# Altium schematic sheet 11_USB.SchDoc
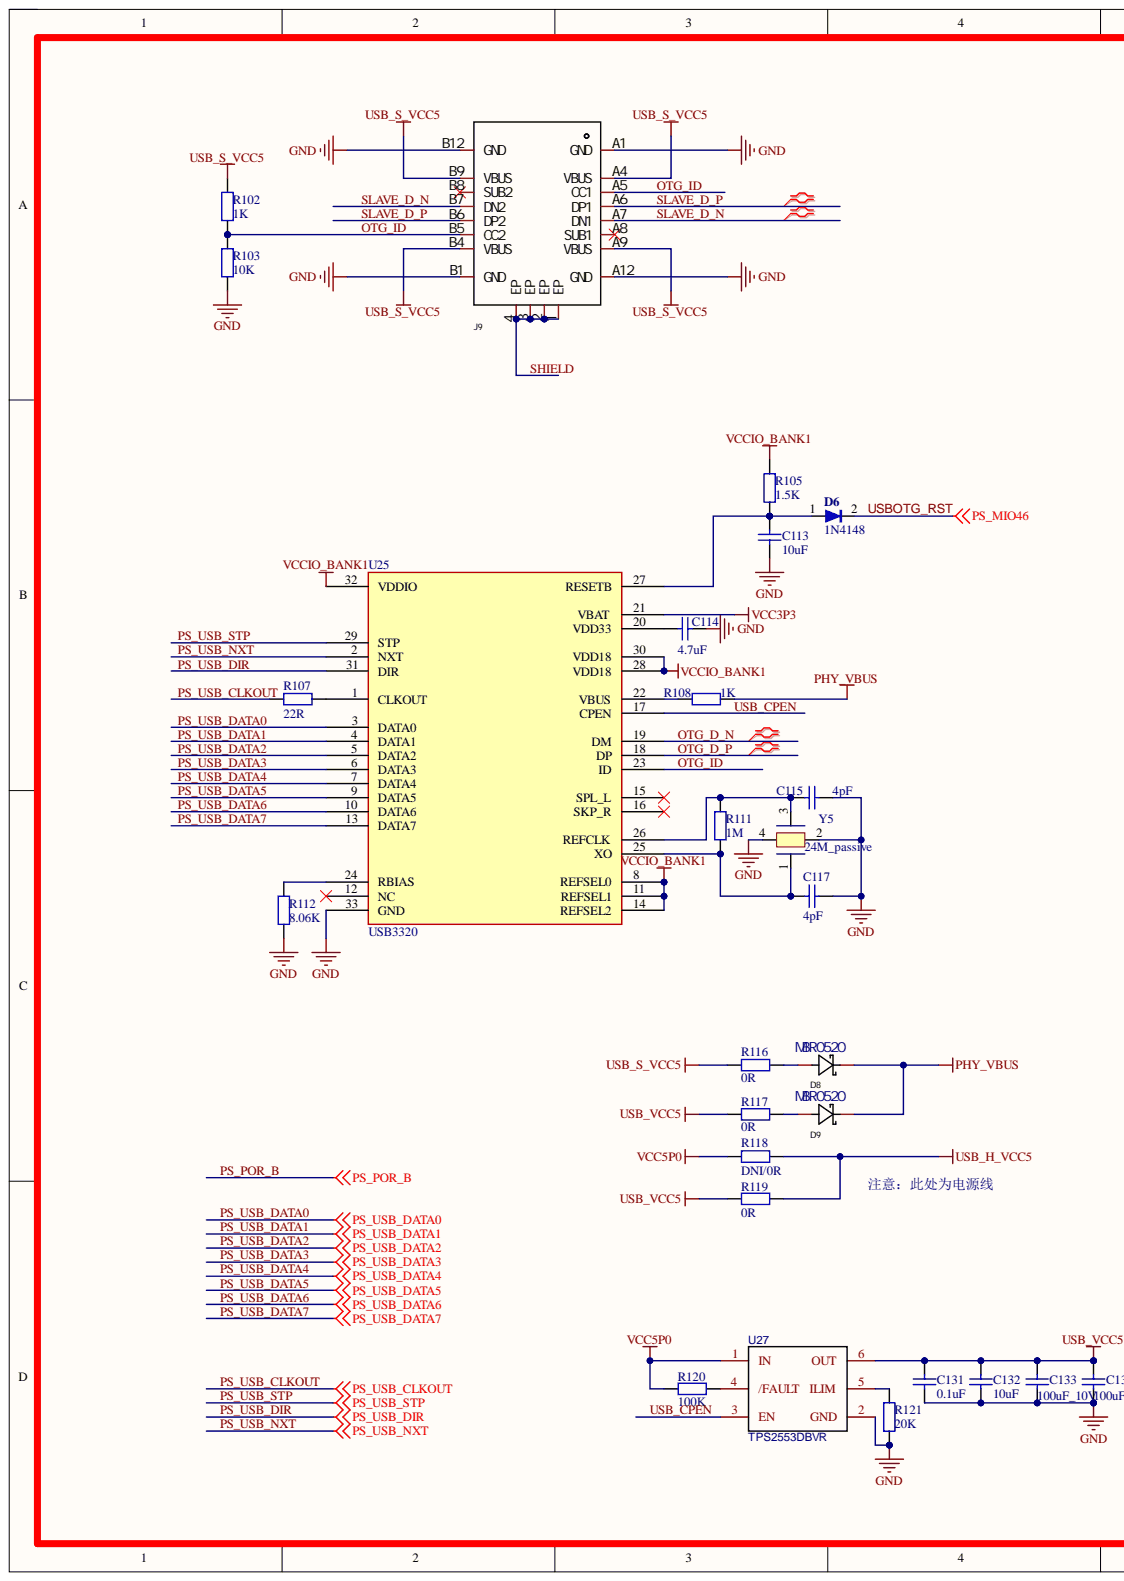
[diagram p0: 11_USB.SchDoc, left]
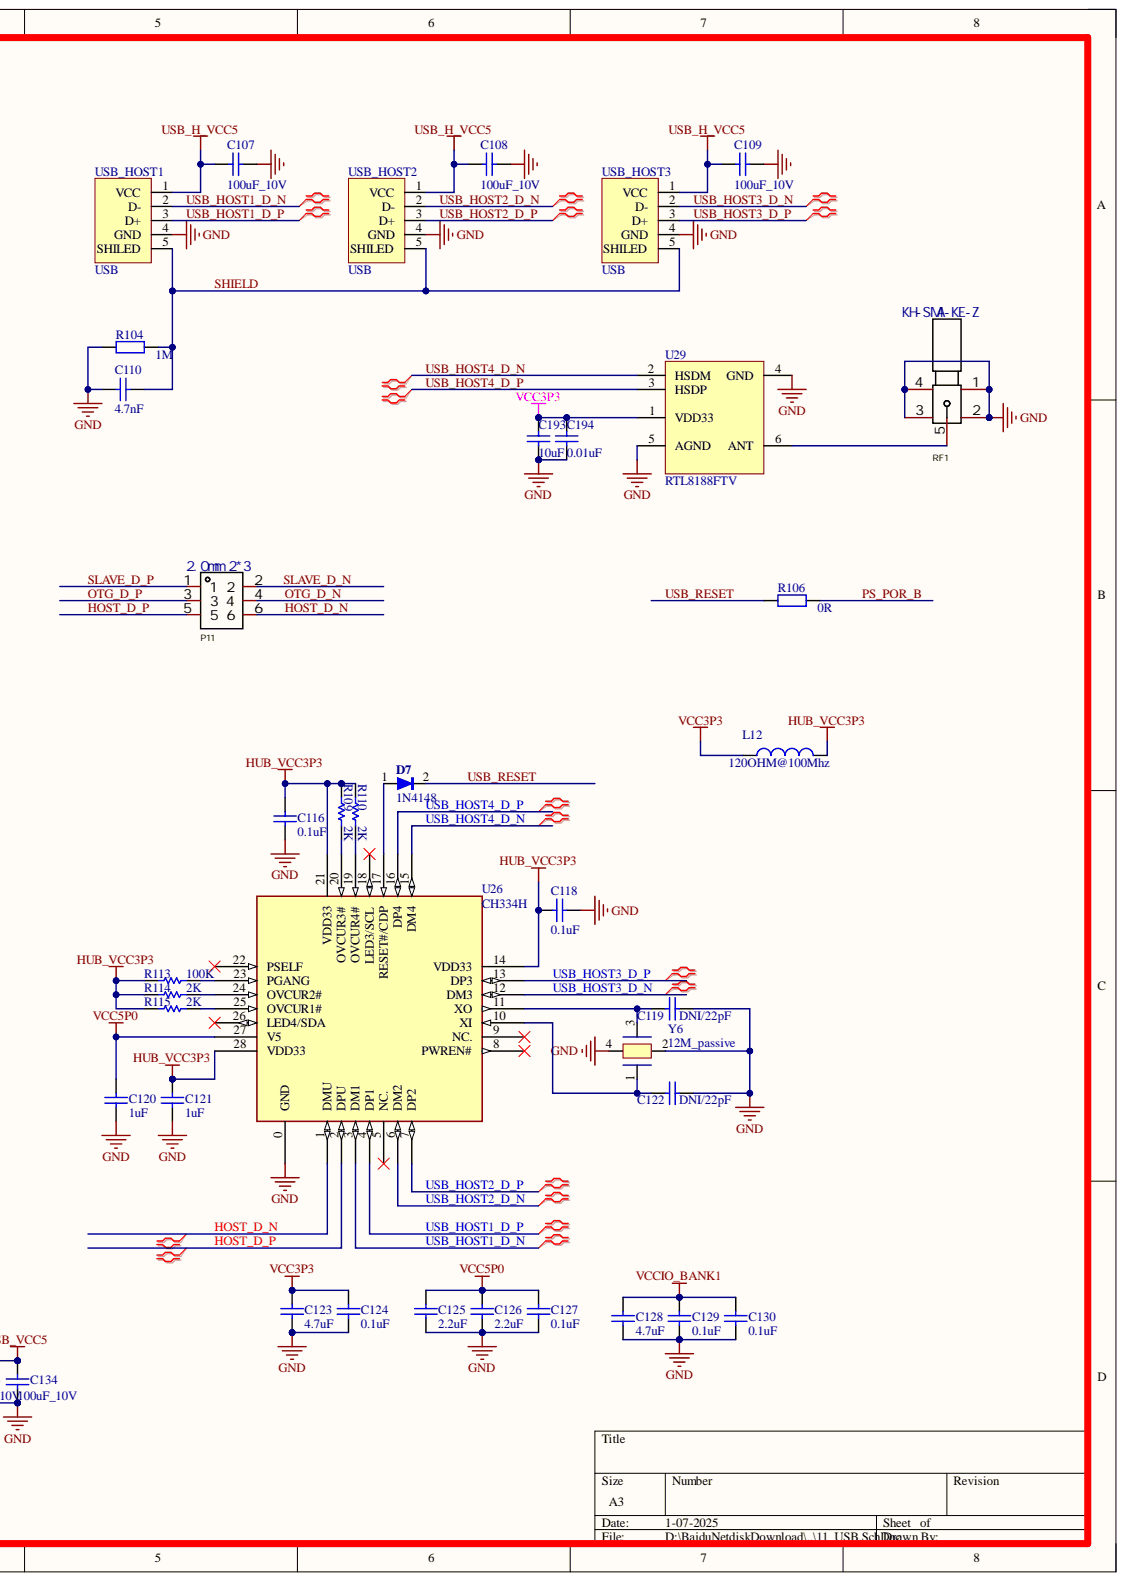
[diagram p1: 11_USB.SchDoc, right]

## Parts
C107  100uF_10V  [C1206]
C108  100uF_10V  [C1206]
C109  100uF_10V  [C1206]
C110  4.7nF  [C1206]
C113  10uF  [C0805]
C114  4.7uF  [C0603]
C115  4pF  [C0603]
C116  0.1uF  [C0603]
C117  4pF  [C0603]
C118  0.1uF  [C0603]
C119  DNI/22pF  [C0603]
C120  1uF  [C0603]
C121  1uF  [C0603]
C122  DNI/22pF  [C0603]
C123  4.7uF  [C0603]
C124  0.1uF  [C0603]
C125  2.2uF  [C0603]
C126  2.2uF  [C0603]
C127  0.1uF  [C0603]
C128  4.7uF  [C0603]
C129  0.1uF  [C0603]
C130  0.1uF  [C0603]
C131  0.1uF  [C0603]
C132  10uF  [C0805]
C133  100uF_10V  [C1206]
C134  100uF_10V  [C1206]
C193  10uF  [C0805]
C194  0.01uF  [C0603]
D6  value 1N4148  [SOD323]  (hidden comment: DIODE1)
D7  1N4148  [SOD323]
D8  MBR0520  [sod-123_l2_7-w1_6-ls3_7-rd-1]
D9  MBR0520  [sod-123_l2_7-w1_6-ls3_7-rd-1]
J9  KH-TYPE-C-16P  [USB_Type-c]  (value not drawn)
L12  120OHM@100Mhz  [L0603]
P11  2.0mm 2*3  [hdr-th_6p-p2_00-v-m-r2-c3-s2_00]
R102  1K  [0603R]
R103  10K  [0603R]
R104  1M  [R1206]
R105  1.5K  [0603R]
R106  0R  [R0603]
R107  22R  [0603R]
R108  1K  [0603R]
R109  2K  [0603R]
R110  2K  [0603R]
R111  1M  [0603R]
R112  8.06K  [0603R]
R113  100K  [0603R]
R114  2K  [0603R]
R115  2K  [0603R]
R116  0R  [R0603]
R117  0R  [R0603]
R118  DNI/0R  [0603R]
R119  0R  [R0603]
R120  100K  [0603R]
R121  20K  [0603R]
RF1  KH-SMA-KE-Z  [SMA-TH_KH-SMA-KE-Z]
U25  USB3320  [qfn-32_l5_0-w5_0-p0_50-bl-ep3_4]
U26  CH334H  [QFN28_5X5]
U27  TPS2553DBVR  [SOT-23-6]
U29  RTL8188FTV  [RL8188FTV]
USB_HOST1  USB  [usb-a_s_e]
USB_HOST2  USB  [usb-a_s_e]
USB_HOST3  USB  [usb-a_s_e]
Y5  24M_passive  [X4-3225]
Y6  12M_passive  [X4-3225]

## Nets
GND: C107.1 C108.1 C109.1 C110.2 C113.1 C114.2 C115.1 C116.2 C117.1 C118.1 C119.1 C120.2 C121.2 C122.1 C123.1 C124.1 C125.1 C126.1 C127.1 C128.1 C129.1 C130.1 C131.1 C132.1 C133.1 C134.1 C193.1 C194.1 J9.A1(GND) J9.A12(GND) J9.B1(GND) J9.B12(GND) R103.1 R104.2 R112.1 R121.2 RF1.1 RF1.2 RF1.3 RF1.4 U25.33(GND) U26.0(GND) U27.2(GND) U29.4(GND) U29.5(AGND) USB_HOST1.4(GND) USB_HOST2.4(GND) USB_HOST3.4(GND) Y5.2 Y5.4 Y6.2 Y6.4
HUB_VCC3P3: C116.1 C118.2 C121.1 L12.2 R109.1 R110.1 R113.1 R114.1 R115.1 U26.14(VDD33) U26.21(VDD33) U26.28(VDD33)
PHY_VBUS: D8.1 D9.1 R108.1
USB_H_VCC5: C107.2 C108.2 C109.2 R118.1 R119.2 USB_HOST1.1(VCC) USB_HOST2.1(VCC) USB_HOST3.1(VCC)
USB_S_VCC5: J9.A4(VBUS) J9.A9(VBUS) J9.B4(VBUS) J9.B9(VBUS) R102.2 R116.2
USB_VCC5: C131.2 C132.2 C133.2 C134.2 R117.1 R119.1 U27.6(OUT)
VCC3P3: C123.2 C124.2 C193.2 C194.2 L12.1 U25.21(VBAT) U29.1(VDD33)
VCC5P0: C120.1 C125.2 C126.2 C127.2 R118.2 R120.1 U26.27(V5) U27.1(IN)
VCCIO_BANK1: C128.2 C129.2 C130.2 R105.1 U25.8(REFSEL0) U25.11(REFSEL1) U25.14(REFSEL2) U25.28(VDD18) U25.30(VDD18) U25.32(VDDIO)
HOST_D_N: P11.6 U26.1(DMU)
HOST_D_P: P11.5 U26.2(DPU)
OTG_D_N: P11.4 U25.19(DM)
OTG_D_P: P11.3 U25.18(DP)
OTG_ID: J9.A5(CC1) J9.B5(CC2) R102.1 R103.2 U25.23(ID)
PS_POR_B: R106.1
PS_USB_CLKOUT: R107.2
PS_USB_DATA0: U25.3(DATA0)
PS_USB_DATA1: U25.4(DATA1)
PS_USB_DATA2: U25.5(DATA2)
PS_USB_DATA3: U25.6(DATA3)
PS_USB_DATA4: U25.7(DATA4)
PS_USB_DATA5: U25.9(DATA5)
PS_USB_DATA6: U25.10(DATA6)
PS_USB_DATA7: U25.13(DATA7)
PS_USB_DIR: U25.31(DIR)
PS_USB_NXT: U25.2(NXT)
PS_USB_STP: U25.29(STP)
SHIELD: C110.1 J9.1(EP) J9.2(EP) J9.3(EP) J9.4(EP) R104.1 USB_HOST1.5(SHILED) USB_HOST2.5(SHILED) USB_HOST3.5(SHILED)
SLAVE_D_N: J9.A7(DN1) J9.B7(DN2) P11.2
SLAVE_D_P: J9.A6(DP1) J9.B6(DP2) P11.1
USB_CPEN: U25.17(CPEN) U27.3(EN)
USB_HOST1_D_N: U26.3(DM1) USB_HOST1.2(D-)
USB_HOST1_D_P: U26.4(DP1) USB_HOST1.3(D+)
USB_HOST2_D_N: U26.6(DM2) USB_HOST2.2(D-)
USB_HOST2_D_P: U26.7(DP2) USB_HOST2.3(D+)
USB_HOST3_D_N: U26.12(DM3) USB_HOST3.2(D-)
USB_HOST3_D_P: U26.13(DP3) USB_HOST3.3(D+)
USB_HOST4_D_N: U26.15(DM4) U29.2(HSDM)
USB_HOST4_D_P: U26.16(DP4) U29.3(HSDP)
USB_RESET: D7.2 R106.2
USBOTG_RST: D6.2
NetC113_2: C113.2 D6.1 R105.2 U25.27(RESETB)
NetC114_1: C114.1 U25.20(VDD33)
NetC115_2: C115.2 R111.2 U25.26(REFCLK) Y5.3
NetC117_2: C117.2 R111.1 U25.25(XO) Y5.1
NetC119_2: C119.2 U26.11(XO) Y6.3
NetC122_2: C122.2 U26.10(XI) Y6.1
NetD7_1: D7.1 U26.17(RESET#/CDP)
NetD8_2: D8.2 R116.1
NetD9_2: D9.2 R117.2
NetR107_1: R107.1 U25.1(CLKOUT)
NetR108_2: R108.2 U25.22(VBUS)
NetR109_2: R109.2 U26.20(OVCUR3#)
NetR110_2: R110.2 U26.19(OVCUR4#)
NetR112_2: R112.2 U25.24(RBIAS)
NetR113_2: R113.2 U26.23(PGANG)
NetR114_2: R114.2 U26.24(OVCUR2#)
NetR115_2: R115.2 U26.25(OVCUR1#)
NetR120_2: R120.2 U27.4(/FAULT)
NetR121_1: R121.1 U27.5(ILIM)
NetRF1_5: RF1.5 U29.6(ANT)

Not connected: J9 A8(SUB1) B8(SUB2); U25 12(NC) 15(SPL_L) 16(SKP_R); U26 5(NC.) 8(PWREN#) 9(NC.) 18(LED3/SCL) 22(PSELF) 26(LED4/SDA)

## Library data of the parts
C107-C110 C113-C115 C117 C123-C134 C193 C194 = Cap: Capacitor; Component Kind: Standard; LatestRevisionDate: 17-Jul-2002; LatestRevisionNote: Re-released for DXP Platform.; Library Name: Miscellaneous Devices.IntLib; PackageDescription: Radial Cap, Thru-Hole; 2 Leads; 0.3 in Pin Spacing; PackageReference: RAD-0.3; Published: 8-Jun-2000; Signal Integrity: Cap; Simulation: CAP; Publisher: Altium Limited
  C107-C109 C133 C134: Value: 100uF_10V
  C110: Value: 4.7nF
  C113 C132 C193: Value: 10uF
  C114 C123 C128: Value: 4.7uF
  C115 C117: Value: 4pF
  C124 C127 C129-C131: Value: 0.1uF
  C125 C126: Value: 2.2uF
  C194: Value: 0.01uF
C116 C118 = Cap_1: Capacitor; Component Kind: Standard; LatestRevisionDate: 17-Jul-2002; LatestRevisionNote: Re-released for DXP Platform.; Library Name: zynq.SCHLIB; PackageDescription: Radial Cap, Thru-Hole; 2 Leads; 0.3 in Pin Spacing; PackageReference: RAD-0.3; Published: 8-Jun-2000; Signal Integrity: Cap; Simulation: CAP; Value: 0.1uF; Publisher: Altium Limited
C119 C122 = CAP_18: Value: 22pF; Component Kind: Standard; Description: CAP_18; Library Name: zynq.SCHLIB
C120 C121 = Cap_8: Capacitor; Component Kind: Standard; LatestRevisionDate: 17-Jul-2002; LatestRevisionNote: Re-released for DXP Platform.; Library Name: zynq.SCHLIB; PackageDescription: Radial Cap, Thru-Hole; 2 Leads; 0.3 in Pin Spacing; PackageReference: RAD-0.3; Published: 8-Jun-2000; Signal Integrity: Cap; Simulation: CAP; Value: 1uF; Publisher: Altium Limited
D6 = (no symbol name): 1N4148,SOD-323,RoHS; 名称: 二极管; 物料代码: 1.04.07.0027; 设备封装名称: SOD-323_1N4148; 贴片和直插: SMT; Component Kind: Standard; ComponentLink1Description: ErpDataInfo; ComponentLink1URL: http://erp.zlgmcu.com/ErpExt/ErpData/ListMateriel.aspx?pkey=1.04.07.0027; Library Name: zynq.SCHLIB; RoHS: RoHS; 型号: 1N4148; 封装: SOD-323
D7 = 1.04.07.0027: 1N4148,SOD-323,RoHS; Database Table Name: VErpDataInfo; 名称: 二极管; 设备封装名称: SOD-323_1N4148; 贴片和直插: SMT; Component Kind: Standard; ComponentLink1Description: ErpDataInfo; ComponentLink1URL: http://erp.zlgmcu.com/ErpExt/ErpData/ListMateriel.aspx?pkey=1.04.07.0027; Library Name: ErpMaterialData.DbLib; RoHS: RoHS; Value: 1N4148; 型号: 1N4148; 封装: SOD-323; 物料代码: 1.04.07.0027
D8 D9 = MBR0520: Manufacturer: YANGJIE(扬杰); Add into BOM: yes; Average Rectified Current (Io): 500mA; Forward Voltage (Vf@If): 450mV@500mA; Reverse Voltage (Vr): 20V; Symbol: MBR0520_C699111; Component Kind: Standard; Convert to PCB: yes; Datasheet: https://atta.szlcsc.com/upload/public/pdf/source/20200709/C699108_94C8F1A54679DD603C9696C8694A3BC2.pdf; Description: MBR0520; Device: MBR0520; JLCPCB Part Class: Extended Part; LCSC Part Name: 20V 500mA 450mV@500mA; Library Name: zynq.SCHLIB; Manufacturer Part: MBR0520; PCB3D: SOD-123_L2.7-W1.6-LS3.7-RD-1; Supplier: LCSC; Supplier Footprint: SOD-123; Supplier Part: C699111
J9 = KH-TYPE-C-16P: Manufacturer: kinghelm(金航标); Add into BOM: yes; Connector Type: Type-C; Current Rating - Power (Max): 3A; Gender: 母座; Number of Contacts: 16; Number of Ports: 1; Component Kind: Standard; Convert to PCB: yes; Datasheet: https://atta.szlcsc.com/upload/public/pdf/source/20200911/C709357_AA8DCEB38BB20E3035A7ED7AE7667837.pdf; Device: KH-TYPE-C-16P; JLCPCB Part Class: Extended Part; LCSC Part Name: Type-C 母座 卧贴; Manufacturer Part: KH-TYPE-C-16P; Mounting Style: 卧贴; Name: KH-TYPE-C-16P; Operating Temperature Range: -40℃~+85℃; PCB3D: USB-C-SMD_KH-TYPE-C-16P; Standard: -; Supplier: LCSC; Supplier Footprint: SMD; Supplier Part: C709357; Symbol: KH-TYPE-C-16P; Library Name: Gowin.SchLib
L12 = Inductor: Code_IEC: 1005; Value: 100ohm/1.2A; Code_JEITA: 0402; Component Kind: Standard; Description: Inductor; LatestRevisionDate: 29-May-2009; LatestRevisionNote: IPC-7351 Footprint Added.; Library Name: Miscellaneous Devices.IntLib; PackageDescription: Chip Inductor; PackageReference: 0402-A; Published: 8-Jun-2000; Publisher: Altium Limited
P11 = 2.0mm 2*3: Manufacturer: HCTL(华灿天禄); Add into BOM: yes; Circluar Pins/Square Pins: 方针; Current Rating (Max): 1.5A; Device: PZ200-2-03-Z; Pin Structure: 2x3P; Pitch: 2mm; Color: 黑色; Component Kind: Standard; Convert to PCB: yes; Datasheet: https://atta.szlcsc.com/upload/public/pdf/source/20211108/81EC9D0128C817B17F97D545889289A1.pdf; Description: 2.0mm 2*3; Insulation Height: 2mm; JLCPCB Part Class: Extended Part; LCSC Part Name: 2mm 2x3P 直插 方针; Length of End Connection Pin: 2.8mm; Length of Mating Pin: 3.9mm; Library Name: zynq.SCHLIB; Manufacturer Part: PZ200-2-03-Z; Mounting Type: 直插; Name: PZ200-2-03-Z; Number of Pins: 6; Number of Rows: 2; Operating Temperature Range: -40℃~+105℃; Row Spacing: 2mm; Supplier: LCSC; Supplier Footprint: 直插,P=2mm; Supplier Part: C2905989; Symbol: PZ200-2-03-Z; Voltage Rating (Max): -
R102-R108 R111 R112 R116-R121 = Res2: Resistor; Component Kind: Standard; LatestRevisionDate: 17-Jul-2002; LatestRevisionNote: Re-released for DXP Platform.; PackageReference: AXIAL-0.4; Published: 8-Jun-2000; Library Name: 元件封装库.pcblib; Publisher: Altium Limited
  R102 R108: Value: 1K
  R103: Value: 10K
  R104 R111: Value: 1M
  R105: Value: 1.5K
  R106 R116 R117 R119: Value: 0R
  R107: Value: 22R
  R112: Value: 8.06K
  R118: Value: DNI/0R
  R120: Value: 100K
  R121: Value: 20K
R109 R110 R114 R115 = Res1_21: Resistor; Component Kind: Standard; LatestRevisionDate: 17-Jul-2002; LatestRevisionNote: Re-released for DXP Platform.; Library Name: zynq.SCHLIB; PackageDescription: Axial Device, Thru-Hole; 2 Leads; 0.3 in Pin Spacing; PackageReference: AXIAL-0.3; Published: 8-Jun-2000; Signal Integrity: Res; Simulation: RESISTOR; Value: 2K; Publisher: Altium Limited
R113 = Res1_19: Resistor; Component Kind: Standard; LatestRevisionDate: 17-Jul-2002; LatestRevisionNote: Re-released for DXP Platform.; Library Name: zynq.SCHLIB; PackageDescription: Axial Device, Thru-Hole; 2 Leads; 0.3 in Pin Spacing; PackageReference: AXIAL-0.3; Published: 8-Jun-2000; Signal Integrity: Res; Simulation: RESISTOR; Value: 100K; Publisher: Altium Limited
RF1 = KH-SMA-KE-Z: Manufacturer: kinghelm(金航标); Add into BOM: yes; Connector Type: 板端; Interface Diameter: 6.2mm; Interface Length/Height: 8mm; Interface Type: 内孔; Number of Ports: 1; RF Series: SMA; Sytle: 正脚; Component Kind: Standard; Convert to PCB: yes; Datasheet: https://atta.szlcsc.com/upload/public/pdf/source/20200330/C504007_C31FA59B5EDC95FA4ACB3324B1A20151.pdf; Device: KH-SMA-KE-Z; Frequency-Max: -; Impedance: -; JLCPCB Part Class: Extended Part; LCSC Part Name: SMA镀金半牙板端直插座子,H=8MM; Library Name: Schlib1.SchLib; Manufacturer Part: KH-SMA-KE-Z; Name: KH-SMA-KE-Z; Operating Temperature Range: -; PCB3D: ANT-TH_L6.5-W6.5-H13.5-P5.08; Supplier: LCSC; Supplier Footprint: 插件; Supplier Part: C504007; Symbol: KH-SMA-KE-Z
U26 = CH334H: 4 ports High-Speed USB MTT Hub with 4 over current detect; Component Kind: Standard; Library Name: CHXXX.schlib
U27 = TPS2553DBVR: BOM_Manufacturer: TI; BOM_JLCPCB Part Class: Extended Part; BOM_Manufacturer Part: TPS2553DBVR; BOM_Supplier: LCSC; BOM_Supplier Part: C55266; Component Kind: Standard; Library Name: zynq.SCHLIB; spicePre: U; spiceSymbolName: TPS2553DBVR
USB_HOST1-USB_HOST3 = USB-A/S: USB-A型母接口; 版权归属: 源创客; BOM名称: USB-A型母接口; Component Kind: Standard; Library Name: IMX6UL_ALPHA(底板)_V2.0.IntLib; 学习资源: www.ooocke.com
Y5 = OSC: Component Kind: Standard; Library Name: SCHLIB.SchLib
Y6 = OSC_2: Component Kind: Standard; Description: 12M_passive; Library Name: zynq.SCHLIB
U25 = USB3320: Component Kind: Standard; Library Name: Sheet1.SCHLIB
U29 = RTL8188FTV: Component Kind: Standard; Description: RTL8188FTV; LatestRevisionDate: 17-Jul-2002; LatestRevisionNote: Re-released for DXP Platform.; Library Name: Sheet1.SCHLIB; Publisher: Altium Limited
